# Altium schematic sheet ESPZ2Mv1.0_RELSchDoc.SchDoc
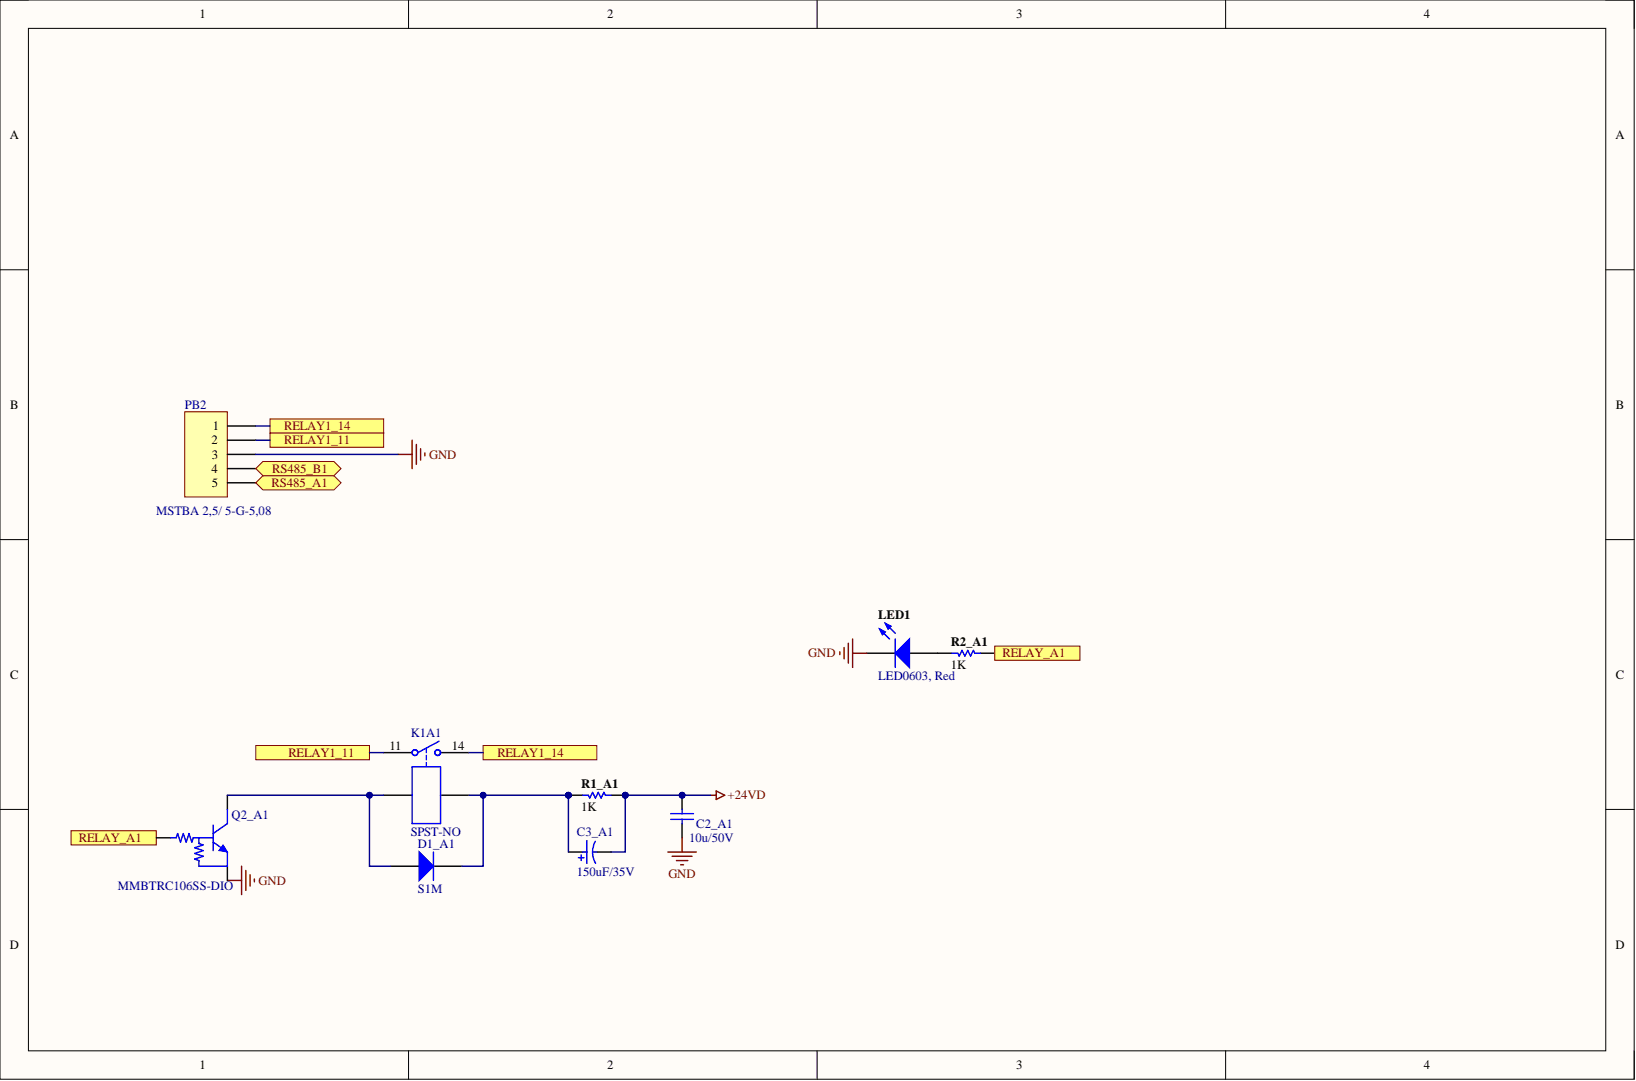
[diagram p0: ESPZ2Mv1.0_RELSchDoc.SchDoc]

## Parts
C2_A1  10u/50V  [CAPC3216L]
C3_A1  150uF/35V  [CAPP_SMD-6,3X7,7]
D1_A1  S1M  [SMA]
K1A1  SPST-NO  [Relay_HF115F]
LED1  LED0603, Red  [LED0603_R]
PB2  MSTBA 2,5/ 5-G-5,08  [MSTBA 2,5/ 5-G-5,08]
Q2_A1  MMBTRC106SS-DIO  [SOT23_N]
R1_A1  1K  [RESC3216L]
R2_A1  1K  [RESC1608L]

## Ports
RELAY1_11, RELAY1_14, RELAY_A1, RS485_A1, RS485_B1

## Nets
+24VD: C2_A1.1 C3_A1.2 R1_A1.1
GND: C2_A1.2 LED1.2 PB2.3 Q2_A1.2
RELAY1_11: K1A1.11 PB2.2 ; port RELAY1_11
RELAY1_14: K1A1.14 PB2.1 ; port RELAY1_14
RELAY_A1: Q2_A1.1 R2_A1.1 ; port RELAY_A1
RS485_A1: PB2.5 ; port RS485_A1
RS485_B1: PB2.4 ; port RS485_B1
NetC3_A1_1: C3_A1.1 D1_A1.2 K1A1.A1 R1_A1.2
NetD1_A1_1: D1_A1.1 K1A1.A2 Q2_A1.3
NetLED1_1: LED1.1 R2_A1.2

## Library data of the parts
C2_A1 = Cap Semi: Capacitor Chip, 1206; Category: Capacitor; Component Kind: Standard; Library Name: Lib-USER.IntLib; Mounting Technology: Surface Mount; PackageReference: 1206; Price: 0,127; Value: 10u/50V
C3_A1 = CAP-EL_SMD: Capacitor, Elec., SMD, Radial; Rated Voltage: 35V; Tolerance: 20 %; Category: Capacitor; Component Kind: Standard; Library Name: Lib-USER.IntLib; Mounting Technology: Surface Mount; PackageReference: CAPP SMD-6,3X7,7; Price: 0,141; Value: 150uF/35V
D1_A1 = Diode S1M: Diode rectifying, SMA; Category: Diode; Component Kind: Standard; Library Name: Lib-USER.IntLib; Mounting Technology: Surface Mount; PackageReference: SMA; Price: 0,028; Value: S1M
K1A1 = Relay-SPST: Relay, SPST, 5VDC, 16A; PartNumber: HF115F-S/5-HSF; PartNumber_1: RM85-5021-25-1024; Value: RM85-5021-25-1024; Category: Relays; Component Kind: Standard; Library Name: Lib-USER.IntLib; Mounting Technology: Thru-Hole; PackageReference: HF115F; Price: 3,00
LED1 = LED_SMD_0603: Category: LED; Component Kind: Standard; Description: LED0603, Red; Library Name: Lib-USER.IntLib; Mounting Technology: Surface Mount; PackageReference: LED0603; Price: 0,04; Value: LED0603, Red
PB2 = MSTB 2,5/ 5-G-5,08: Connector, PCB Header, 5-Pin, 5.08mm; Value: PH-1759046; Category: Connector; Component Kind: Standard; Library Name: Lib-Connectors.IntLib; Mounting Technology: Thru-Hole; PackageReference: MSTB 2,5/ 5-G-5,08; PartNumber: MSTB 2,5/ 5-G-5,08; Price: 0,1025
Q2_A1 = Transistor Digital NPN: Transistor Digital (BRT), NPN; PartNumber: MMUN2233LT1G; PartNumber_1: PDTC143ZT,215; Category: Transistors Digital; Component Kind: Standard; Library Name: Lib-USER.IntLib; Mounting Technology: Surface Mount; PackageReference: SC-75/SOT416; Price: 0,017; Value: MMBTRC106SS-DIO
R1_A1 R2_A1 = Res Semi: Resistor, 1% 0603 (1608); Category: Resistor; Component Kind: Standard; Library Name: Lib-USER.SCHLIB; Mounting Technology: Surface Mount; PackageReference: 0603; Price: 0,002; Tolerance: 1%; Value: 1K
